# Altium schematic sheet PAGE2-RASPBERRY PI.SchDoc
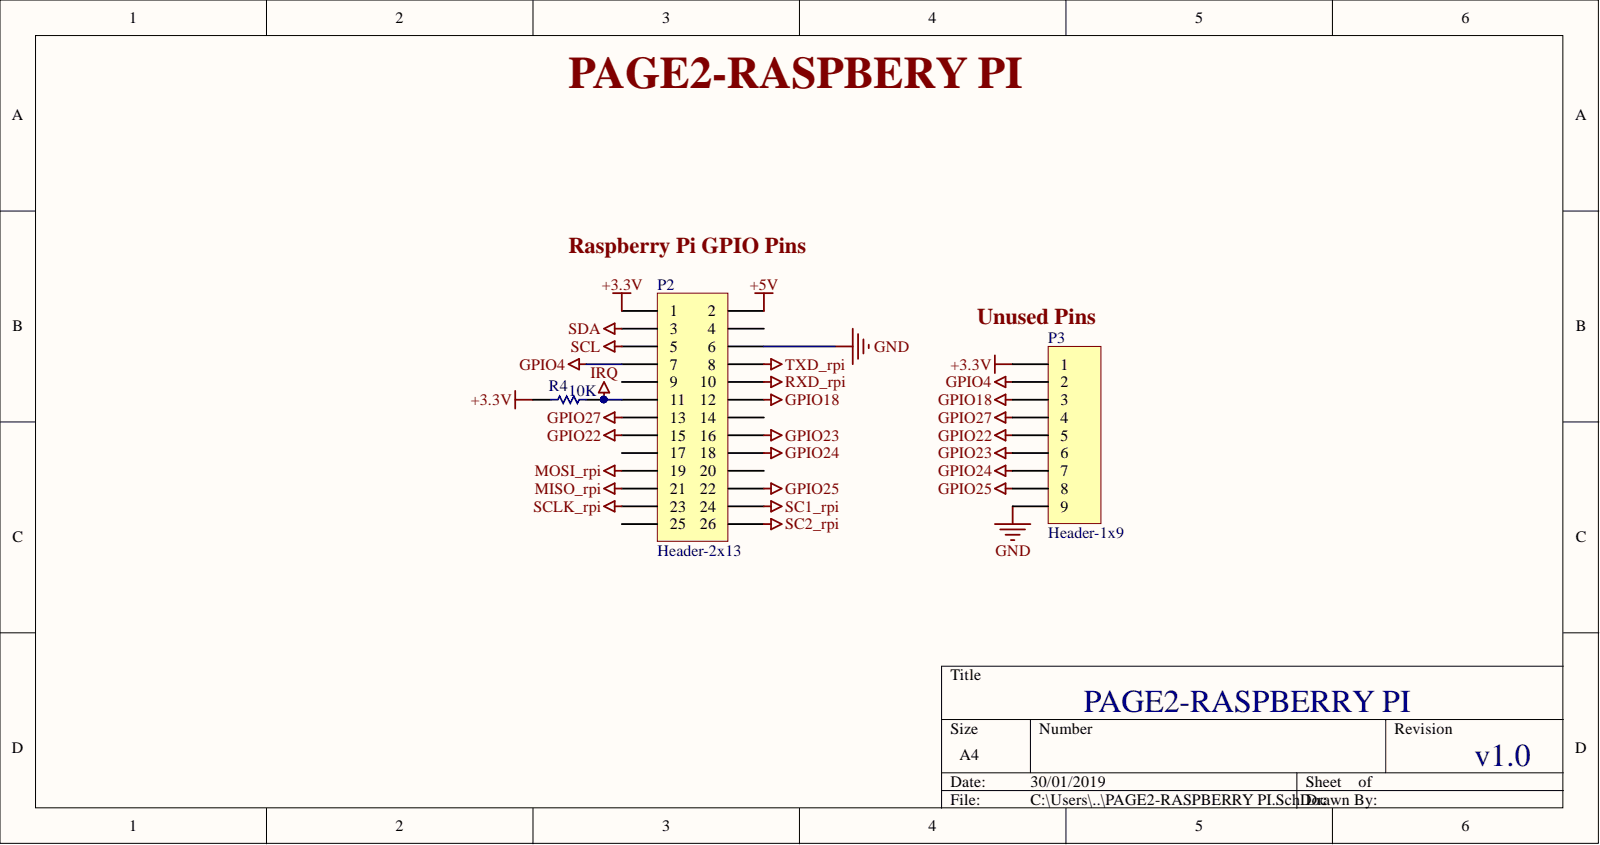
[diagram p0: PAGE2-RASPBERRY PI.SchDoc]

## Parts
P2  Header-2x13  [HDR2X13]
P3  Header-1x9  [HDR_1X9]
R4  value 10K  [1206_res]  (hidden comment: res)

## Nets
+3.3V: P2.1 P3.1 R4.1
+5V: P2.2
GND: P2.6 P3.9
GPIO4: P2.7 P3.2
GPIO18: P2.12 P3.3
GPIO22: P2.15 P3.5
GPIO23: P2.16 P3.6
GPIO24: P2.18 P3.7
GPIO25: P2.22 P3.8
GPIO27: P2.13 P3.4
IRQ: P2.11 R4.2
MISO_RPI: P2.21
MOSI_RPI: P2.19
RXD_RPI: P2.10
SC1_RPI: P2.24
SC2_RPI: P2.26
SCL: P2.5
SCLK_RPI: P2.23
SDA: P2.3
TXD_RPI: P2.8

Not connected: P2 4 9 14 17 20 25

## Library data of the parts
P2 = Header 13X2: Header, 13-Pin, Dual row; Component Kind: Standard; LatestRevisionDate: 17-Jul-2002; LatestRevisionNote: Re-released for DXP Platform.; Library Name: Miscellaneous Connectors.IntLib; Signal Integrity: Connector; Publisher: Altium Limited
P3 = header_9: Header, 9-Pin; Component Kind: Standard; LatestRevisionDate: 17-Jul-2002; LatestRevisionNote: Re-released for DXP Platform.; Signal Integrity: Connector; Library Name: hepek_lib.SchLib; Publisher: Altium Limited
R4 = res: Resistor; Component Kind: Standard; LatestRevisionDate: 17-Jul-2002; LatestRevisionNote: Re-released for DXP Platform.; PackageReference: AXIAL-0.3; Published: 8-Jun-2000; Signal Integrity: Res; Simulation: RESISTOR; Library Name: hepek_lib.SchLib; Publisher: Altium Limited
